# Altium schematic sheet Sheet3.SchDoc(Sheet3)
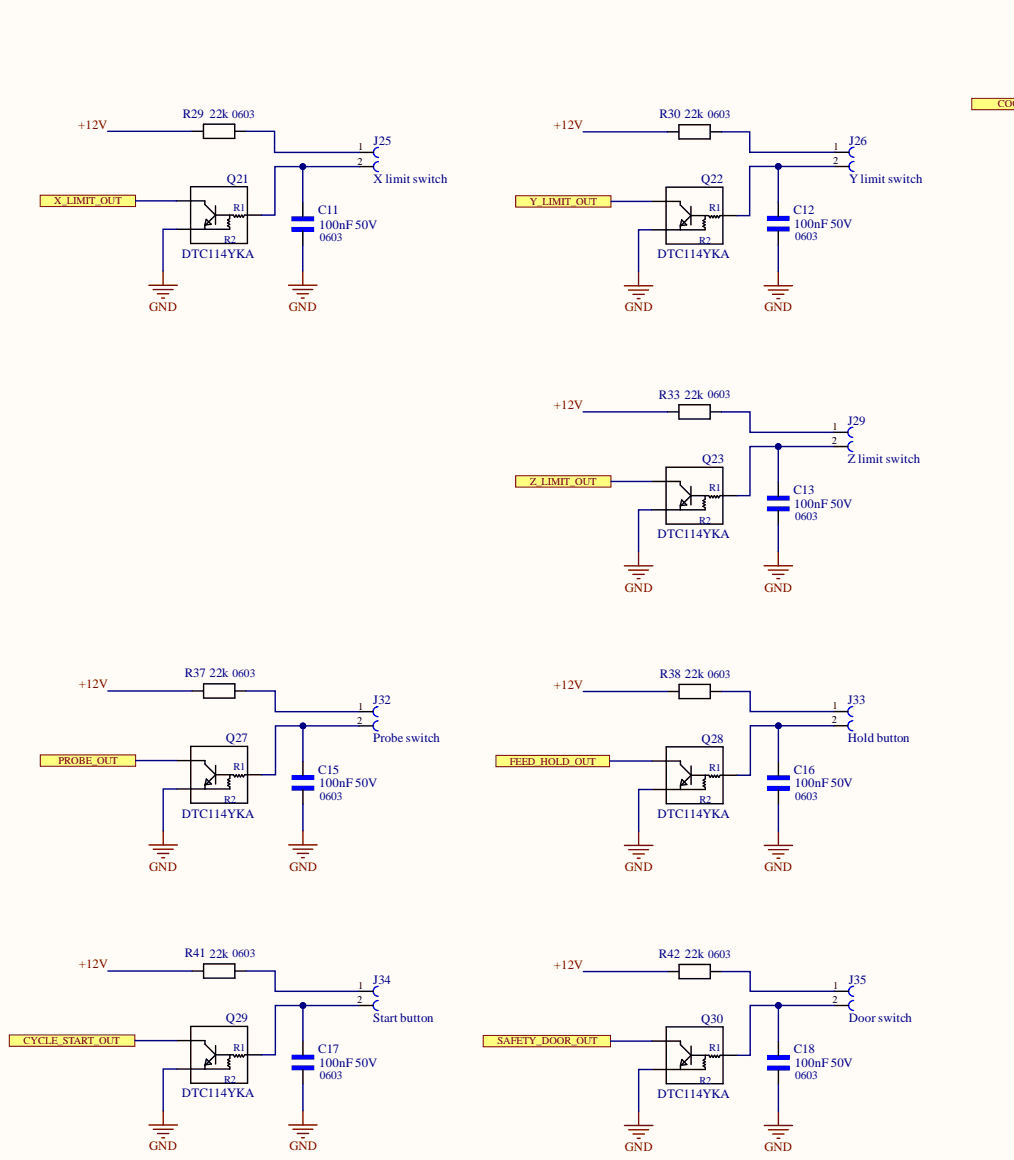
[diagram p0: Sheet3.SchDoc, left]
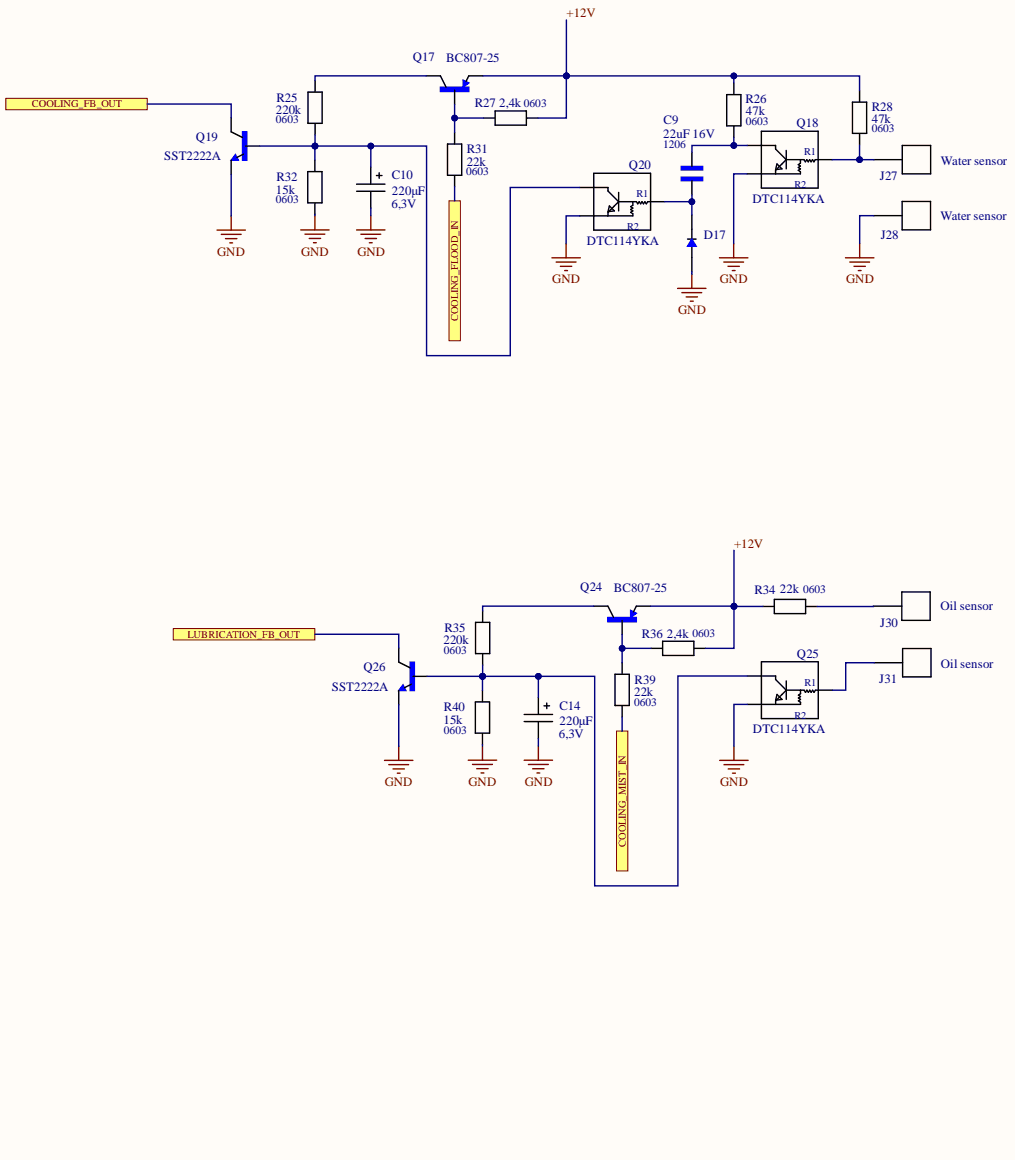
[diagram p1: Sheet3.SchDoc, right]

## Parts
C9  22uF 16V  Case: 1206  [SMD 1206 CAP]
C10  220μF  Voltage: 6,3V  [CAP 6.3x12]
C11  100nF 50V  Case: 0603  [SMD 0603 CAP]
C12  100nF 50V  Case: 0603  [SMD 0603 CAP]
C13  100nF 50V  Case: 0603  [SMD 0603 CAP]
C14  220μF  Voltage: 6,3V  [CAP 6.3x12]
C15  100nF 50V  Case: 0603  [SMD 0603 CAP]
C16  100nF 50V  Case: 0603  [SMD 0603 CAP]
C17  100nF 50V  Case: 0603  [SMD 0603 CAP]
C18  100nF 50V  Case: 0603  [SMD 0603 CAP]
D17  1N4148W  [SOD123]  (value not drawn)
J25  X limit switch  [PN1X2]
J26  Y limit switch  [PN1X2]
J27  Water sensor  [Wire-2]
J28  Water sensor  [Wire-2]
J29  Z limit switch  [PN1X2]
J30  Oil sensor  [Wire-2]
J31  Oil sensor  [Wire-2]
J32  Probe switch  [PN1X2]
J33  Hold button  [PN1X2]
J34  Start button  [PN1X2]
J35  Door switch  [PN1X2]
Q17  BC807-25  [SOT-23-3]
Q18  DTC114YKA  [SOT-346 (SC59) Black]
Q19  SST2222A  [SOT-23-3]
Q20  DTC114YKA  [SOT-346 (SC59) Black]
Q21  DTC114YKA  [SOT-346 (SC59) Black]
Q22  DTC114YKA  [SOT-346 (SC59) Black]
Q23  DTC114YKA  [SOT-346 (SC59) Black]
Q24  BC807-25  [SOT-23-3]
Q25  DTC114YKA  [SOT-346 (SC59) Black]
Q26  SST2222A  [SOT-23-3]
Q27  DTC114YKA  [SOT-346 (SC59) Black]
Q28  DTC114YKA  [SOT-346 (SC59) Black]
Q29  DTC114YKA  [SOT-346 (SC59) Black]
Q30  DTC114YKA  [SOT-346 (SC59) Black]
R25  220k  Case: 0603  [SMD 0603]
R26  47k  Case: 0603  [SMD 0603]
R27  2,4k  Case: 0603  [SMD 0603]
R28  47k  Case: 0603  [SMD 0603]
R29  22k  Case: 0603  [SMD 0603]
R30  22k  Case: 0603  [SMD 0603]
R31  22k  Case: 0603  [SMD 0603]
R32  15k  Case: 0603  [SMD 0603]
R33  22k  Case: 0603  [SMD 0603]
R34  22k  Case: 0603  [SMD 0603]
R35  220k  Case: 0603  [SMD 0603]
R36  2,4k  Case: 0603  [SMD 0603]
R37  22k  Case: 0603  [SMD 0603]
R38  22k  Case: 0603  [SMD 0603]
R39  22k  Case: 0603  [SMD 0603]
R40  15k  Case: 0603  [SMD 0603]
R41  22k  Case: 0603  [SMD 0603]
R42  22k  Case: 0603  [SMD 0603]

## Ports
COOLING_FB_OUT, COOLING_FLOOD_IN, COOLING_MIST_IN, CYCLE_START_OUT, FEED_HOLD_OUT, LUBRICATION_FB_OUT, PROBE_OUT, SAFETY_DOOR_OUT, X_LIMIT_OUT, Y_LIMIT_OUT, Z_LIMIT_OUT

## Nets
GND: C10.2 C11.1 C12.1 C13.1 C14.2 C15.1 C16.1 C17.1 C18.1 D17.A J28.1 Q18.2 Q19.2 Q20.2 Q21.2 Q22.2 Q23.2 Q25.2 Q26.2 Q27.2 Q28.2 Q29.2 Q30.2 R32.2 R40.2
+12V: Q17.2 Q24.2 R26.2 R27.2 R28.2 R29.1 R30.1 R33.1 R34.1 R36.2 R37.1 R38.1 R41.1 R42.1
COOLING_FB_OUT: Q19.3 ; port COOLING_FB_OUT
COOLING_FLOOD_IN: R31.2 ; port COOLING_FLOOD_IN
COOLING_MIST_IN: R39.2 ; port COOLING_MIST_IN
CYCLE_START_OUT: Q29.3 ; port CYCLE_START_OUT
FEED_HOLD_OUT: Q28.3 ; port FEED_HOLD_OUT
LUBRICATION_FB_OUT: Q26.3 ; port LUBRICATION_FB_OUT
PROBE_OUT: Q27.3 ; port PROBE_OUT
SAFETY_DOOR_OUT: Q30.3 ; port SAFETY_DOOR_OUT
X_LIMIT_OUT: Q21.3 ; port X_LIMIT_OUT
Y_LIMIT_OUT: Q22.3 ; port Y_LIMIT_OUT
Z_LIMIT_OUT: Q23.3 ; port Z_LIMIT_OUT
NetC9_1: C9.1 D17.C Q20.1
NetC9_2: C9.2 Q18.3 R26.1
NetC10_1: C10.1 Q19.1 Q20.3 R25.2 R32.1
NetC11_2: C11.2 J25.2 Q21.1
NetC12_2: C12.2 J26.2 Q22.1
NetC13_2: C13.2 J29.2 Q23.1
NetC14_1: C14.1 Q25.3 Q26.1 R35.2 R40.1
NetC15_2: C15.2 J32.2 Q27.1
NetC16_2: C16.2 J33.2 Q28.1
NetC17_2: C17.2 J34.2 Q29.1
NetC18_2: C18.2 J35.2 Q30.1
NetJ25_1: J25.1 R29.2
NetJ26_1: J26.1 R30.2
NetJ27_1: J27.1 Q18.1 R28.1
NetJ29_1: J29.1 R33.2
NetJ30_1: J30.1 R34.2
NetJ31_1: J31.1 Q25.1
NetJ32_1: J32.1 R37.2
NetJ33_1: J33.1 R38.2
NetJ34_1: J34.1 R41.2
NetJ35_1: J35.1 R42.2
NetQ17_1: Q17.1 R27.1 R31.1
NetQ17_3: Q17.3 R25.1
NetQ24_1: Q24.1 R36.1 R39.1
NetQ24_3: Q24.3 R35.1

## Library data of the parts
C9 = CL32B106KLJNNNE: 22uF 16V X5R +-20%; Manufacturer: SAMSUNG ELECTRO-MECHANICS; Model: CL31A226MOCLNNC; Component Kind: Standard; HelpURL: https://store.comet.bg/Catalogue/Product/17773/; Library Name: CAN_Library.IntLib; Value: 22uF 16V
C10 C14 = CAP Aluminium: Electrolytic capacitor, RM series, 20%,-40~105°C, 6.3x7mm RM2.5; Manufacturer: FUJICON; Case: 6.3x7.0x2.5; Component Kind: Standard; HelpURL: https://store.comet.bg/Catalogue/Product/5122/; Library Name: CAN_Library.IntLib; Temperature: -55...+105°C; Value: 220μF
C11-C13 C15-C18 = CL32B106KLJNNNE: 100nF 50V X7R +-10%; Manufacturer: SAMSUNG ELECTRO-MECHANICS; Component Kind: Standard; HelpURL: https://store.comet.bg/Catalogue/Product/5963/; Library Name: CAN_Library.IntLib; Model: CL32B106KLJNNNE; Value: 100nF 50V
D17 = 1N4148W: Diode Standard 100V 300mA _DC_ Surface Mount SOD-123; MF: DIODES / ZETEX; MP: 1N4148W-7-F; Case: SOD-123 Diodes Inc.; Component Kind: Standard; HelpURL: https://store.comet.bg/Catalogue/Product/9613/; Library Name: CAN_Library.IntLib; Value: 1N4148W
J25 J26 J29 J32-J35 = PN1X2: Single Row / Straight / Without Shroud Female header Female 2@ Pin header, socket _Si...; Value: PN1X2; Case: 0,8 mm; Component Kind: Standard; Library Name: CAN_Library.IntLib
J27 J28 J30 J31 = Wire: Case: 0,8mm; Component Kind: Standard; Description: Wire; Library Name: CAN_Library.IntLib; Value: Wire
Q17 Q24 = BC807-25: Transistor PNP 45V 500mA 0.25W HFE 160-400; Manufacturer: NEXPERIA; Case: SOT-23; Component Kind: Standard; Library Name: CAN_Library.IntLib; Value: BC807-25
Q18 Q20-Q23 Q25 Q27-Q30 = DTC114YKA: NPN Digital 50V 100mA 200mW  R1=10kOm R2=47kOm; Manufacturer: ROHM Semiconductor; Case: SOT-346 (SC59); Component Kind: Standard; HalpURL: https://store.comet.bg/Catalogue/Product/23715/; Library Name: CAN_Library.IntLib; Value: DTC114YKA
Q19 Q26 = SST2222A: Transistor NPN 40V 600mA 300MHz 350mW; Manufacturer: ROHM Semiconductor; Case: SOT-23-3 SST3; Component Kind: Standard; HelpURL: https://store.comet.bg/Catalogue/Product/23715/; Library Name: CAN_Library.IntLib; Value: SST2222A
R25-R42 = Resistor_2: RES; Component Kind: Standard; HelpURL: https://store.comet.bg/Catalogue/RES/Resistors/#eyJpcHAiOiIxMDAiLCJtIjoiIn0%3D; Library Name: CAN_Library.IntLib
  R25 R35: Value: 220k
  R26 R28: Value: 47k
  R27 R36: Value: 2,4k
  R29-R31 R33 R34 R37-R39 R41 R42: Value: 22k
  R32 R40: Value: 15k
